# Altium schematic sheet Power_15V.SchDoc("Power 15V")
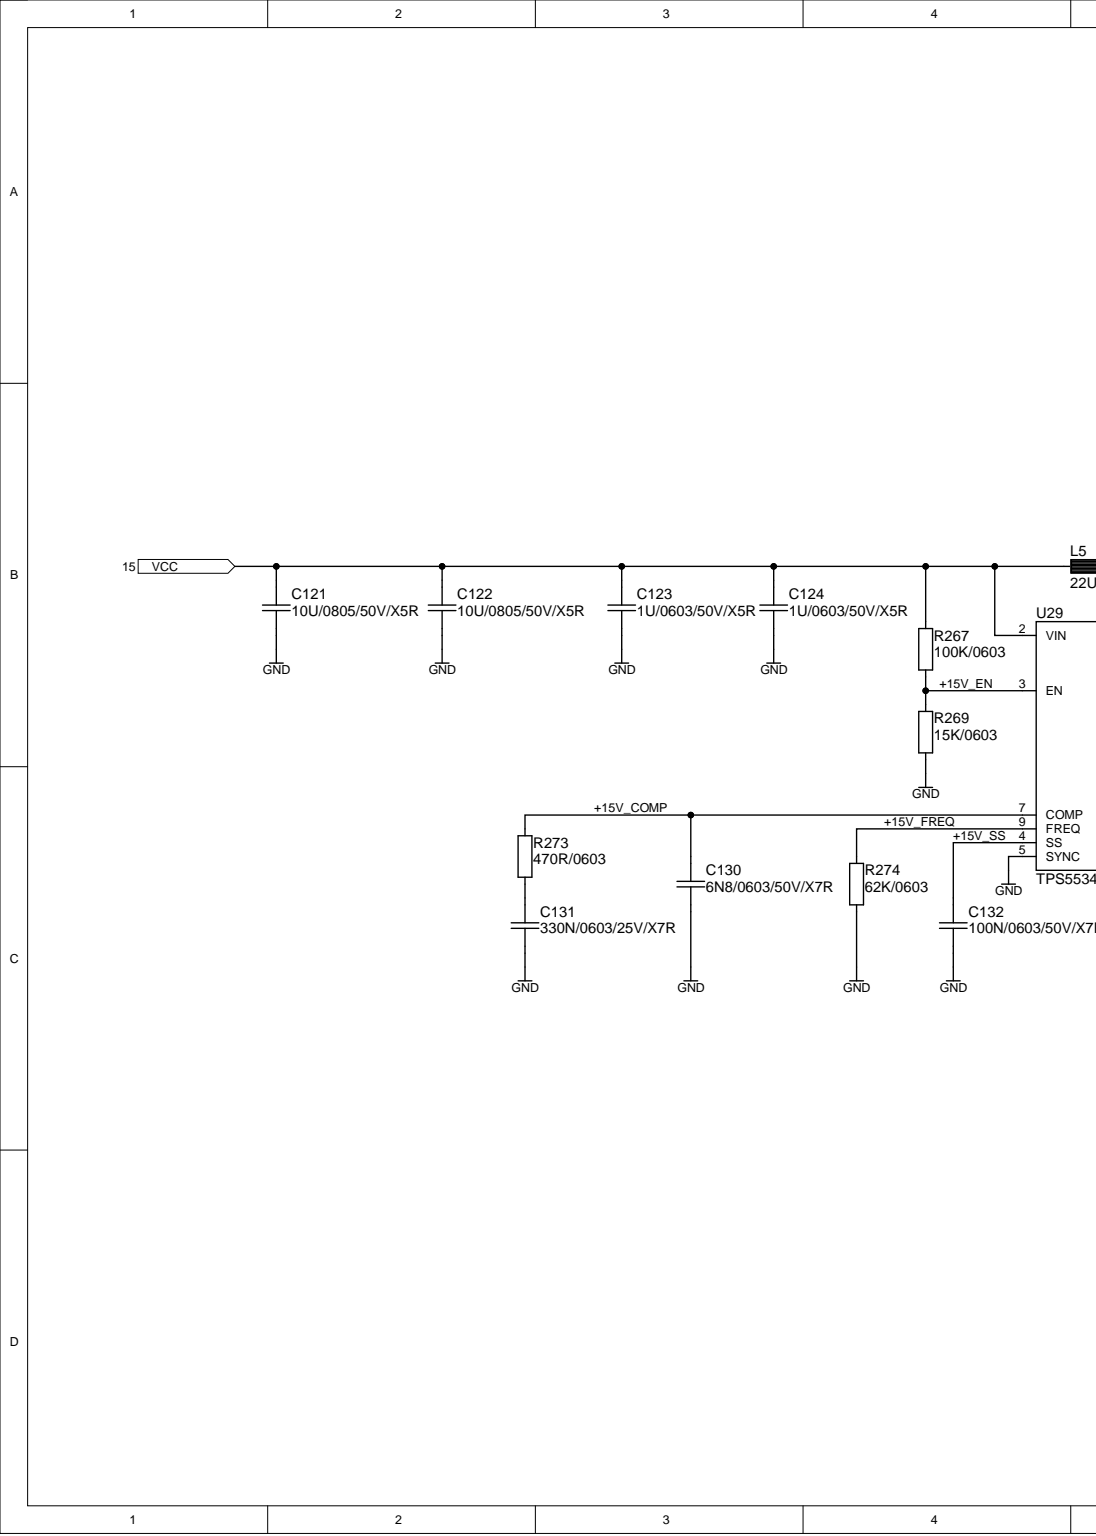
[diagram p0: Power_15V.SchDoc, left]
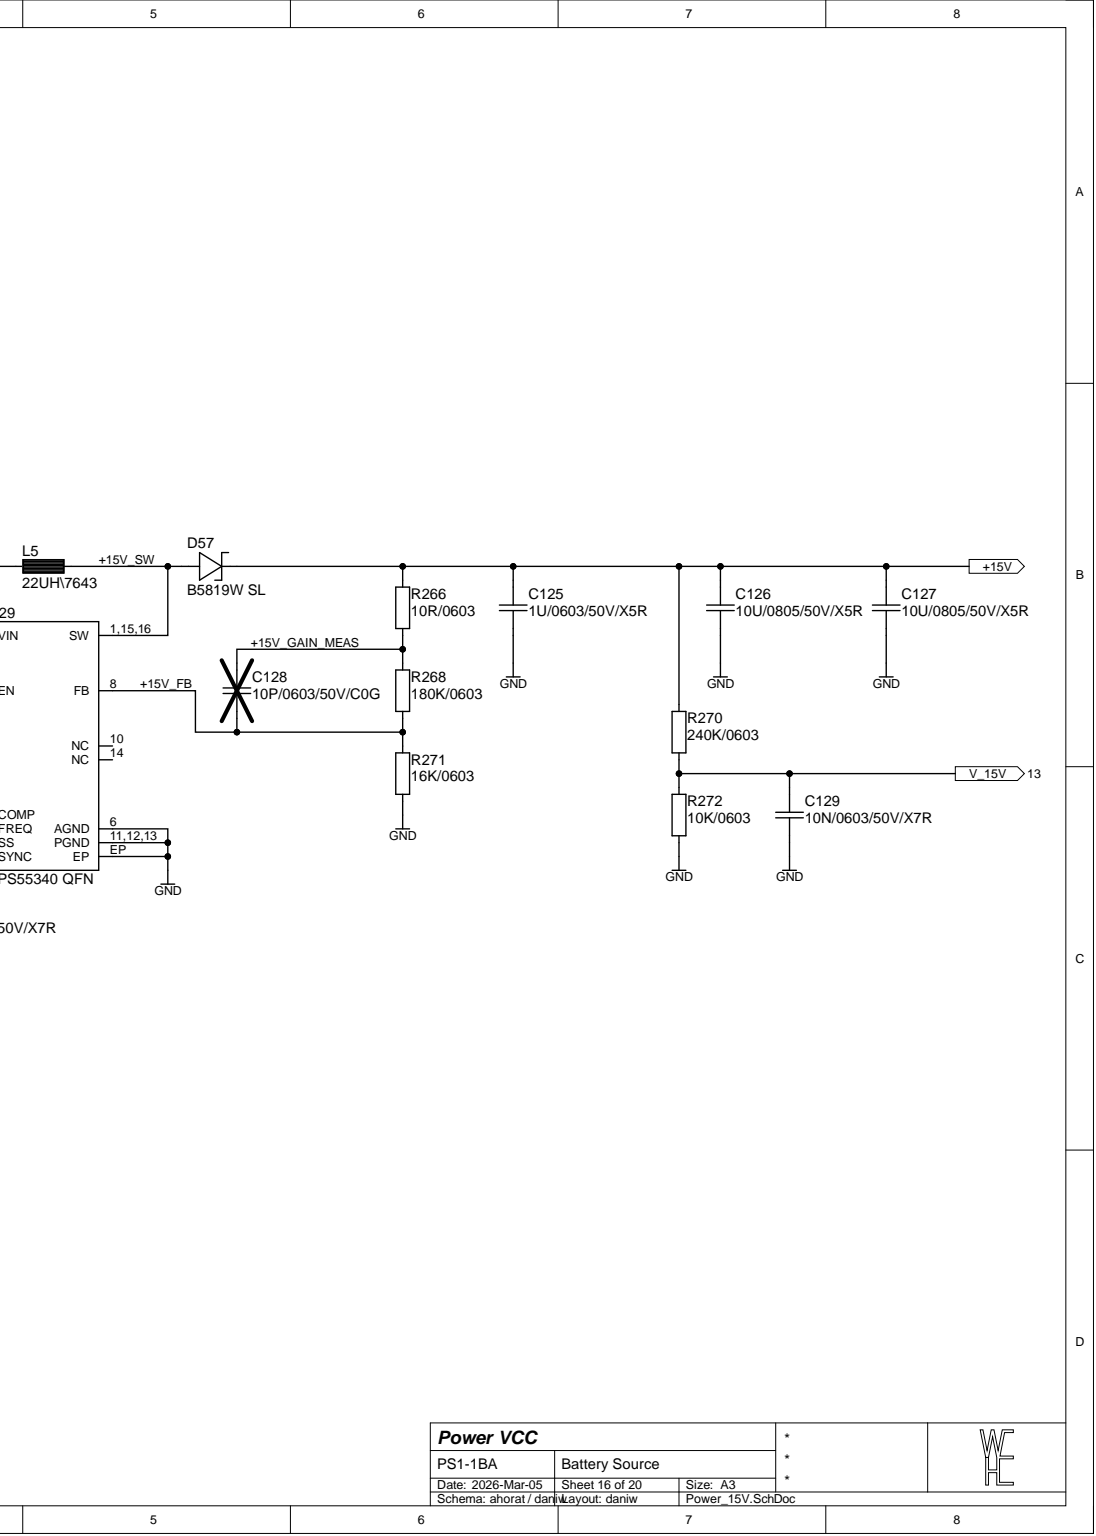
[diagram p1: Power_15V.SchDoc, right]

## Parts
C121  10U/0805/50V/X5R  [CAP0805_X7R]
C122  10U/0805/50V/X5R  [CAP0805_X7R]
C123  1U/0603/50V/X5R  [CAP0603_X7R]
C124  1U/0603/50V/X5R  [CAP0603_X7R]
C125  1U/0603/50V/X5R  [CAP0603_X7R]
C126  10U/0805/50V/X5R  [CAP0805_X7R]
C127  10U/0805/50V/X5R  [CAP0805_X7R]
C128  10P/0603/50V/C0G  [CAP0603_C0G]
C129  10N/0603/50V/X7R  [CAP0603_X7R]
C130  6N8/0603/50V/X7R  [CAP0603_X7R]
C131  330N/0603/25V/X7R  [CAP0603_X7R]
C132  100N/0603/50V/X7R  [CAP0603_X7R]
D57  B5819W SL  [SOD3718X125]
L5  22UH\7643  [INDM7676X43]
R266  10R/0603  [R0603-0.40-WW]
R267  100K/0603  [R0603-0.40-WW]
R268  180K/0603  [R0603-0.40-WW]
R269  15K/0603  [R0603-0.40-WW]
R270  240K/0603  [R0603-0.40-WW]
R271  16K/0603  [R0603-0.40-WW]
R272  10K/0603  [R0603-0.40-WW]
R273  470R/0603  [R0603-0.40-WW]
R274  62K/0603  [R0603-0.40-WW]
U29  TPS55340 QFN  [QFN050P300X300X080-16-EP168X168]

## Ports
+15V, V_15V, VCC

## Nets
GND: C121.2 C122.2 C123.2 C124.2 C125.2 C126.2 C127.2 C129.2 C130.2 C131.2 C132.2 R269.2 R271.2 R272.2 R274.2 U29.5(SYNC) U29.6(AGND) U29.11,12,13(PGND) U29.EP
+15V: C125.1 C126.1 C127.1 D57.1 R266.1 R270.1 ; port +15V
+15V_COMP: C130.1 R273.1 U29.7(COMP)
+15V_EN: R267.2 R269.1 U29.3(EN)
+15V_FB: C128.2 R268.2 R271.1 U29.8(FB)
+15V_FREQ: R274.1 U29.9(FREQ)
+15V_GAIN_MEAS: C128.1 R266.2 R268.1
+15V_SS: C132.1 U29.4(SS)
+15V_SW: D57.2 L5.2 U29.1,15,16(SW)
V_15V: C129.1 R270.2 R272.1 ; port V_15V
VCC: C121.1 C122.1 C123.1 C124.1 L5.1 R267.1 U29.2(VIN) ; port VCC
NetC131_1: C131.1 R273.2
NetU29_10: U29.10(NC)
NetU29_14: U29.14(NC)

## Library data of the parts
C121 C122 C126 C127 = 10U/0805/50V/X5R: CAP SM 0805 10uF 50V X5R; Manufacturer: GENERIC; Manufacturer 1: Murata Electronics; Manufacturer Part Number 1: GRM21BR61H106KE43K; Absolute Value: 1E-5; Database Table Name: C$; Eurocircuits GPN: GPN0805106-50-X5R; Component Kind: Standard; HelpURL: https://search.murata.co.jp/Ceramy/image/img/A01X/G101/ENG/GRM21BR61H106KE43-01A.pdf; JLC Type: Basic; Manufacturer Part Number 3: GRM21BR61H106KE43L; Package: 0805; Part Number: 10U/0805/50V/X5R; Supplier 1: Digi-Key; Supplier 3: LCSC; Supplier Part Number 1: 490-GRM21BR61H106KE43KCT-ND; Supplier Part Number 3: C440198; Value: 10uF; Library Name: daniw_altium_lib.DbLib
C123-C125 = 1U/0603/50V/X5R: CAP SM 0603 1.0uF 50V X5R; Manufacturer: GENERIC; Manufacturer 1: Samsung Electro-Mechanics; Manufacturer Part Number 1: CL10A105KB8NNNC; Absolute Value: 1E-6; Database Table Name: C$; Eurocircuits GPN: GPC0603105-X5R; Component Kind: Standard; HelpURL: https://mm.digikey.com/Volume0/opasdata/d220001/medias/docus/658/CL10A105KB8NNNC_Spec.pdf; JLC Type: Basic; Manufacturer Part Number 3: CL10A105KB8NNNC; Package: 0603; Part Number: 1U/0603/50V/X5R; Supplier 1: Digi-Key; Supplier 3: LCSC; Supplier Part Number 1: 1276-1860-1-ND; Supplier Part Number 3: C15849; Value: 1.0uF; Library Name: daniw_altium_lib.DbLib
C128 = 10P/0603/50V/C0G: CAP SM 0603 10pF 50V C0G; Manufacturer: GENERIC; Manufacturer 1: YAGEO; Manufacturer Part Number 1: CC0603JRNPO9BN100; Absolute Value: 1E-11; Database Table Name: C$; Eurocircuits GPN: GPC0603100-C0G; Component Kind: Standard; HelpURL: https://www.yageo.com/upload/media/product/productsearch/datasheet/mlcc/UPY-GP_NP0_16V-to-50V_18.pdf; Manufacturer 2: YAGEO; Manufacturer Part Number 2: CC0603KRNPO9BN100; Manufacturer Part Number 3: CL10C100JB8NNNC; Package: 0603; Part Number: 10P/0603/50V/C0G; Supplier 1: Digi-Key; Supplier 2: Digi-Key; Supplier 3: LCSC; Supplier Part Number 1: 311-1058-1-ND; Supplier Part Number 2: 311-4025-1-ND; Supplier Part Number 3: C1634; Value: 10pF; Library Name: daniw_altium_lib.DbLib
C129 = 10N/0603/50V/X7R: CAP SM 0603 10nF 50V X7R; Manufacturer: GENERIC; Manufacturer 1: YAGEO; Manufacturer Part Number 1: CC0603KPX7R9BB103; Absolute Value: 1E-8; Database Table Name: C$; Eurocircuits GPN: GPC0603103; Component Kind: Standard; HelpURL: https://www.yageo.com/upload/media/product/app/datasheet/mlcc/upy-gphc_x7r_6_3v-to-250v.pdf; Manufacturer 2: YAGEO; Manufacturer Part Number 2: CC0603KRX7R9BB103; Manufacturer Part Number 3: CC0603KRX7R9BB103; Package: 0603; Part Number: 10N/0603/50V/X7R; Supplier 1: Digi-Key; Supplier 2: Digi-Key; Supplier 3: LCSC; Supplier Part Number 1: 311-1572-1-ND; Supplier Part Number 2: 311-1085-1-ND; Supplier Part Number 3: C100042; Value: 10nF; Library Name: daniw_altium_lib.DbLib
C130 = 6N8/0603/50V/X7R: CAP SM 0603 6.8nF 50V X7R; Manufacturer: GENERIC; Manufacturer 1: YAGEO; Manufacturer Part Number 1: CC0603KPX7R9BB682; Absolute Value: 6.8E-9; Database Table Name: C$; Eurocircuits GPN: GPC0603682; Component Kind: Standard; HelpURL: https://www.yageo.com/upload/media/product/app/datasheet/mlcc/upy-gphc_x7r_6_3v-to-250v.pdf; JLC Type: Basic; Manufacturer 2: YAGEO; Manufacturer Part Number 2: CC0603KRX7R9BB682; Manufacturer Part Number 3: 0603B682K500NT; Package: 0603; Part Number: 6N8/0603/50V/X7R; Supplier 1: Digi-Key; Supplier 2: Digi-Key; Supplier 3: LCSC; Supplier Part Number 1: 13-CC0603KPX7R9BB682CT-ND; Supplier Part Number 2: 311-1084-1-ND; Supplier Part Number 3: C1631; Value: 6.8nF; Library Name: daniw_altium_lib.DbLib
C131 = 330N/0603/25V/X7R: CAP SM 0603 330nF 25V X7R; Manufacturer: GENERIC; Manufacturer 1: YAGEO; Manufacturer Part Number 1: CC0603KRX7R8BB334; Absolute Value: 3.3E-7; Database Table Name: C$; Component Kind: Standard; HelpURL: https://www.yageogroup.com/content/datasheet/asset/file/UPY-GPHC_X7R_6_3V-TO-250V; JLC Type: Extended; Manufacturer 2: YAGEO; Manufacturer Part Number 2: CC0603JRX7R8BB334; Manufacturer Part Number 3: CC0603KRX7R8BB334; Package: 0603; Part Number: 330N/0603/25V/X7R; Supplier 1: Digi-Key; Supplier 2: Digi-Key; Supplier 3: LCSC; Supplier Part Number 1: 311-3573-1-ND; Supplier Part Number 2: 13-CC0603JRX7R8BB334CT-ND; Supplier Part Number 3: C107070; Value: 330nF; Library Name: daniw_altium_lib.DbLib
C132 = 100N/0603/50V/X7R: CAP SM 0603 100nF 50V X7R; Manufacturer: GENERIC; Manufacturer 1: YAGEO; Manufacturer Part Number 1: CC0603KPX7R9BB104; Absolute Value: 1E-7; Database Table Name: C$; Eurocircuits GPN: GPC0603104; Component Kind: Standard; HelpURL: https://www.yageo.com/upload/media/product/app/datasheet/mlcc/upy-gphc_x7r_6_3v-to-250v.pdf; JLC Type: Basic; Manufacturer 2: YAGEO; Manufacturer Part Number 2: CC0603MRX7R9BB104; Manufacturer Part Number 3: CC0603KRX7R9BB104; Package: 0603; Part Number: 100N/0603/50V/X7R; Supplier 1: Digi-Key; Supplier 2: Digi-Key; Supplier 3: LCSC; Supplier Part Number 1: 311-3567-1-ND; Supplier Part Number 2: 311-4110-1-ND; Supplier Part Number 3: C14663; Value: 100nF; Library Name: daniw_altium_lib.DbLib
D57 = B5819W SL: 40V 600mV@1A 1A SOD-123 Schottky Barrier Diodes; Manufacturer: GENERIC; Manufacturer 1: SMC Diode Solutions; Database Table Name: D$; Absolute Value: 40V; Component Kind: Standard; HelpURL: https://datasheet.lcsc.com/lcsc/2304140030_Jiangsu-Changjing-Electronics-Technology-Co---Ltd--B5819W-SL_C8598.pdf; JLC Type: Basic; Manufacturer 3: Jiangsu Changjing Electronics Technology Co., Ltd.; Manufacturer Part Number 1: B5819WSL; Manufacturer Part Number 3: B5819W SL; Package: SOD-123; Part Number: B5819W SL; Supplier 1: Digikey; Supplier 3: LCSC; Supplier Part Number 1: 1655-B5819WSLCT-ND; Supplier Part Number 3: C8598; Value: B5819W SL; Library Name: daniw_altium_lib.DbLib
L5 = 22UH\7643: FIXED IND 22UH 1.75A 92.5MOHM SM; Manufacturer: Eaton - Electronics Division; Manufacturer Part Number 1: DR74-220-R; Absolute Value: 2.2E-5; Database Table Name: L$; Component Kind: Standard; HelpURL: https://www.eaton.com/content/dam/eaton/products/electronic-components/resources/data-sheet/eaton-dr-high-power-density-high-efficiency-shielded-drum-core-power-inductors-data-sheet.pdf; Manufacturer 1: Eaton - Electronics Division; Manufacturer 3: SXN(Shun Xiang Nuo Elec); Manufacturer Part Number 3: SMDRI74-220M; Package: 7643; Part Number: 22UH\7643; Supplier 1: Digi-Key; Supplier 3: LCSC; Supplier Part Number 1: 513-1390-1-ND; Supplier Part Number 3: C164083; Value: 22UH; Library Name: daniw_altium_lib.DbLib
R266 = 10R/0603: RES SM 0603 10R; Manufacturer: GENERIC; Manufacturer 1: YAGEO; Manufacturer Part Number 1: RC0603FR-1310RL; Absolute Value: 10; Database Table Name: R$; Eurocircuits GPN: GPR060310R; Component Kind: Standard; HelpURL: https://www.yageogroup.com/content/datasheet/asset/file/PYU-RC_GROUP_51_ROHS_L; JLC Type: Basic; Manufacturer 2: YAGEO; Manufacturer 3: UNI-ROYAL; Manufacturer Part Number 2: RC0603FR-1010RL; Manufacturer Part Number 3: 0603WAF100JT5E; Package: 0603; Part Number: 10R/0603; Supplier 1: Digi-Key; Supplier 2: Digi-Key; Supplier 3: LCSC; Supplier Part Number 1: 13-RC0603FR-1310RLCT-ND; Supplier Part Number 2: 13-RC0603FR-1010RLCT-ND; Supplier Part Number 3: C22859; Value: 10R; Library Name: daniw_altium_lib.DbLib
R267 = 100K/0603: RES SM 0603 100K; Manufacturer: GENERIC; Manufacturer 1: YAGEO; Manufacturer Part Number 1: RC0603FR-13100KL; Absolute Value: 100000; Database Table Name: R$; Eurocircuits GPN: GPR0603100K; Component Kind: Standard; HelpURL: https://www.yageogroup.com/content/datasheet/asset/file/PYU-RC_GROUP_51_ROHS_L; JLC Type: Basic; Manufacturer 2: YAGEO; Manufacturer 3: UNI-ROYAL(Uniroyal Elec); Manufacturer Part Number 2: RC0603FR-10100KL; Manufacturer Part Number 3: 0603WAF1003T5E; Package: 0603; Part Number: 100K/0603; Supplier 1: Digi-Key; Supplier 2: Digi-Key; Supplier 3: LCSC; Supplier Part Number 1: 13-RC0603FR-13100KLCT-ND; Supplier Part Number 2: 13-RC0603FR-10100KLCT-ND; Supplier Part Number 3: C25803; Value: 100K; Library Name: daniw_altium_lib.DbLib
R268 = 180K/0603: RES SM 0603 180K; Manufacturer: GENERIC; Manufacturer 1: YAGEO; Manufacturer Part Number 1: RC0603FR-13180KL; Absolute Value: 180000; Database Table Name: R$; Eurocircuits GPN: GPR0603180K; Component Kind: Standard; HelpURL: https://www.yageogroup.com/content/datasheet/asset/file/PYU-RC_GROUP_51_ROHS_L; JLC Type: Extended; Manufacturer 2: YAGEO; Manufacturer 3: UNI-ROYAL(Uniroyal Elec); Manufacturer Part Number 2: RC0603FR-10180KL; Manufacturer Part Number 3: 0603WAF1803T5E; Package: 0603; Part Number: 180K/0603; Supplier 1: Digi-Key; Supplier 2: Digi-Key; Supplier 3: LCSC; Supplier Part Number 1: 13-RC0603FR-13180KLCT-ND; Supplier Part Number 2: 13-RC0603FR-10180KLCT-ND; Supplier Part Number 3: C22827; Value: 180K; Library Name: daniw_altium_lib.DbLib
R269 = 15K/0603: RES SM 0603 15K; Manufacturer: GENERIC; Manufacturer 1: YAGEO; Manufacturer Part Number 1: RC0603FR-1315KL; Absolute Value: 15000; Database Table Name: R$; Eurocircuits GPN: GPR060315K; Component Kind: Standard; HelpURL: https://www.yageogroup.com/content/datasheet/asset/file/PYU-RC_GROUP_51_ROHS_L; JLC Type: Basic; Manufacturer 2: YAGEO; Manufacturer 3: UNI-ROYAL(Uniroyal Elec); Manufacturer Part Number 2: RC0603FR-1015KL; Manufacturer Part Number 3: 0603WAF1502T5E; Package: 0603; Part Number: 15K/0603; Supplier 1: Digi-Key; Supplier 2: Digi-Key; Supplier 3: LCSC; Supplier Part Number 1: 13-RC0603FR-1315KLCT-ND; Supplier Part Number 2: 13-RC0603FR-1015KLCT-ND; Supplier Part Number 3: C22809; Value: 15K; Library Name: daniw_altium_lib.DbLib
R270 = 240K/0603: RES SM 0603 240K; Manufacturer: GENERIC; Manufacturer 1: YAGEO; Manufacturer Part Number 1: RC0603FR-13240KL; Absolute Value: 240000; Database Table Name: R$; Eurocircuits GPN: GPR0603240K; Component Kind: Standard; HelpURL: https://www.yageogroup.com/content/datasheet/asset/file/PYU-RC_GROUP_51_ROHS_L; JLC Type: Extended; Manufacturer 2: YAGEO; Manufacturer 3: UNI-ROYAL(Uniroyal Elec); Manufacturer Part Number 2: RC0603FR-07240KL; Manufacturer Part Number 3: 0603WAF2403T5E; Package: 0603; Part Number: 240K/0603; Supplier 1: Digi-Key; Supplier 2: Digi-Key; Supplier 3: LCSC; Supplier Part Number 1: 13-RC0603FR-13240KLCT-ND; Supplier Part Number 2: 311-240KHRCT-ND; Supplier Part Number 3: C4197; Value: 240K; Library Name: daniw_altium_lib.DbLib
R271 = 16K/0603: RES SM 0603 16K; Manufacturer: GENERIC; Manufacturer 1: YAGEO; Manufacturer Part Number 1: RC0603FR-1316KL; Absolute Value: 16000; Database Table Name: R$; Eurocircuits GPN: GPR060316K; Component Kind: Standard; HelpURL: https://www.yageogroup.com/content/datasheet/asset/file/PYU-RC_GROUP_51_ROHS_L; JLC Type: Extended; Manufacturer 2: YAGEO; Manufacturer 3: YAGEO; Manufacturer Part Number 2: RC0603FR-1016KL; Manufacturer Part Number 3: RC0603FR-0716KL; Package: 0603; Part Number: 16K/0603; Supplier 1: Digi-Key; Supplier 2: Digi-Key; Supplier 3: LCSC; Supplier Part Number 1: 13-RC0603FR-1316KLCT-ND; Supplier Part Number 2: 13-RC0603FR-1016KLCT-ND; Supplier Part Number 3: C137788; Value: 16K; Library Name: daniw_altium_lib.DbLib
R272 = 10K/0603: RES SM 0603 10K; Manufacturer: GENERIC; Manufacturer 1: YAGEO; Manufacturer Part Number 1: RC0603FR-1310KL; Absolute Value: 10000; Database Table Name: R$; Eurocircuits GPN: GPR060310K; Component Kind: Standard; HelpURL: https://www.yageogroup.com/content/datasheet/asset/file/PYU-RC_GROUP_51_ROHS_L; JLC Type: Basic; Manufacturer 2: YAGEO; Manufacturer 3: UNI-ROYAL(Uniroyal Elec); Manufacturer Part Number 2: RC0603FR-1010KL; Manufacturer Part Number 3: 0603WAF1002T5E; Package: 0603; Part Number: 10K/0603; Supplier 1: Digi-Key; Supplier 2: Digi-Key; Supplier 3: LCSC; Supplier Part Number 1: 13-RC0603FR-1310KLCT-ND; Supplier Part Number 2: 13-RC0603FR-1010KLCT-ND; Supplier Part Number 3: C25804; Value: 10K; Library Name: daniw_altium_lib.DbLib
R273 = 470R/0603: RES SM 0603 470R; Manufacturer: GENERIC; Manufacturer 1: YAGEO; Manufacturer Part Number 1: RC0603FR-13470RL; Absolute Value: 470; Database Table Name: R$; Eurocircuits GPN: GPR0603470R; Component Kind: Standard; HelpURL: https://www.yageogroup.com/content/datasheet/asset/file/PYU-RC_GROUP_51_ROHS_L; JLC Type: Basic; Manufacturer 2: YAGEO; Manufacturer 3: UNI-ROYAL; Manufacturer Part Number 2: RC0603FR-10470RL; Manufacturer Part Number 3: 0603WAF4700T5E; Package: 0603; Part Number: 470R/0603; Supplier 1: Digi-Key; Supplier 2: Digi-Key; Supplier 3: LCSC; Supplier Part Number 1: 13-RC0603FR-13470RLCT-ND; Supplier Part Number 2: 13-RC0603FR-10470RLCT-ND; Supplier Part Number 3: C23179; Value: 470R; Library Name: daniw_altium_lib.DbLib
R274 = 62K/0603: RES SM 0603 62K; Manufacturer: GENERIC; Manufacturer 1: YAGEO; Manufacturer Part Number 1: RC0603FR-1362KL; Absolute Value: 62000; Database Table Name: R$; Eurocircuits GPN: GPR060362K; Component Kind: Standard; HelpURL: https://www.yageogroup.com/content/datasheet/asset/file/PYU-RC_GROUP_51_ROHS_L; JLC Type: Extended; Manufacturer 2: YAGEO; Manufacturer 3: UNI-ROYAL(Uniroyal Elec); Manufacturer Part Number 2: RC0603FR-1062KL; Manufacturer Part Number 3: 0603WAF6202T5E; Package: 0603; Part Number: 62K/0603; Supplier 1: Digi-Key; Supplier 2: Digi-Key; Supplier 3: LCSC; Supplier Part Number 1: 13-RC0603FR-1362KLCT-ND; Supplier Part Number 2: 13-RC0603FR-1062KLCT-ND; Supplier Part Number 3: C23221; Value: 62K; Library Name: daniw_altium_lib.DbLib
U29 = TPS55340 QFN: TPS55340 Integrated 5-A Wide Input Range Boost/SEPIC/Flyback DC/DC Regulator; Manufacturer: Texas Instruments; Manufacturer Part Number 1: TPS55340RTER; Database Table Name: Integrated$; Component Kind: Standard; HelpURL: https://www.ti.com/lit/ds/symlink/tps55340.pdf; JLC Type: Extended; Manufacturer 1: Texas Instruments; Manufacturer 2: Texas Instruments; Manufacturer 3: TI; Manufacturer Part Number 2: TPS55340RTET; Manufacturer Part Number 3: TPS55340RTER; Package: QFN-16; Part Number: TPS55340 QFN; Supplier 1: Digi-Key; Supplier 2: Digi-Key; Supplier 3: LCSC; Supplier Part Number 1: 296-37677-1-ND; Supplier Part Number 2: 296-30530-1-ND; Supplier Part Number 3: C169167; Library Name: daniw_altium_lib.DbLib
